# Altium schematic sheet Powering.SchDoc(Powering)
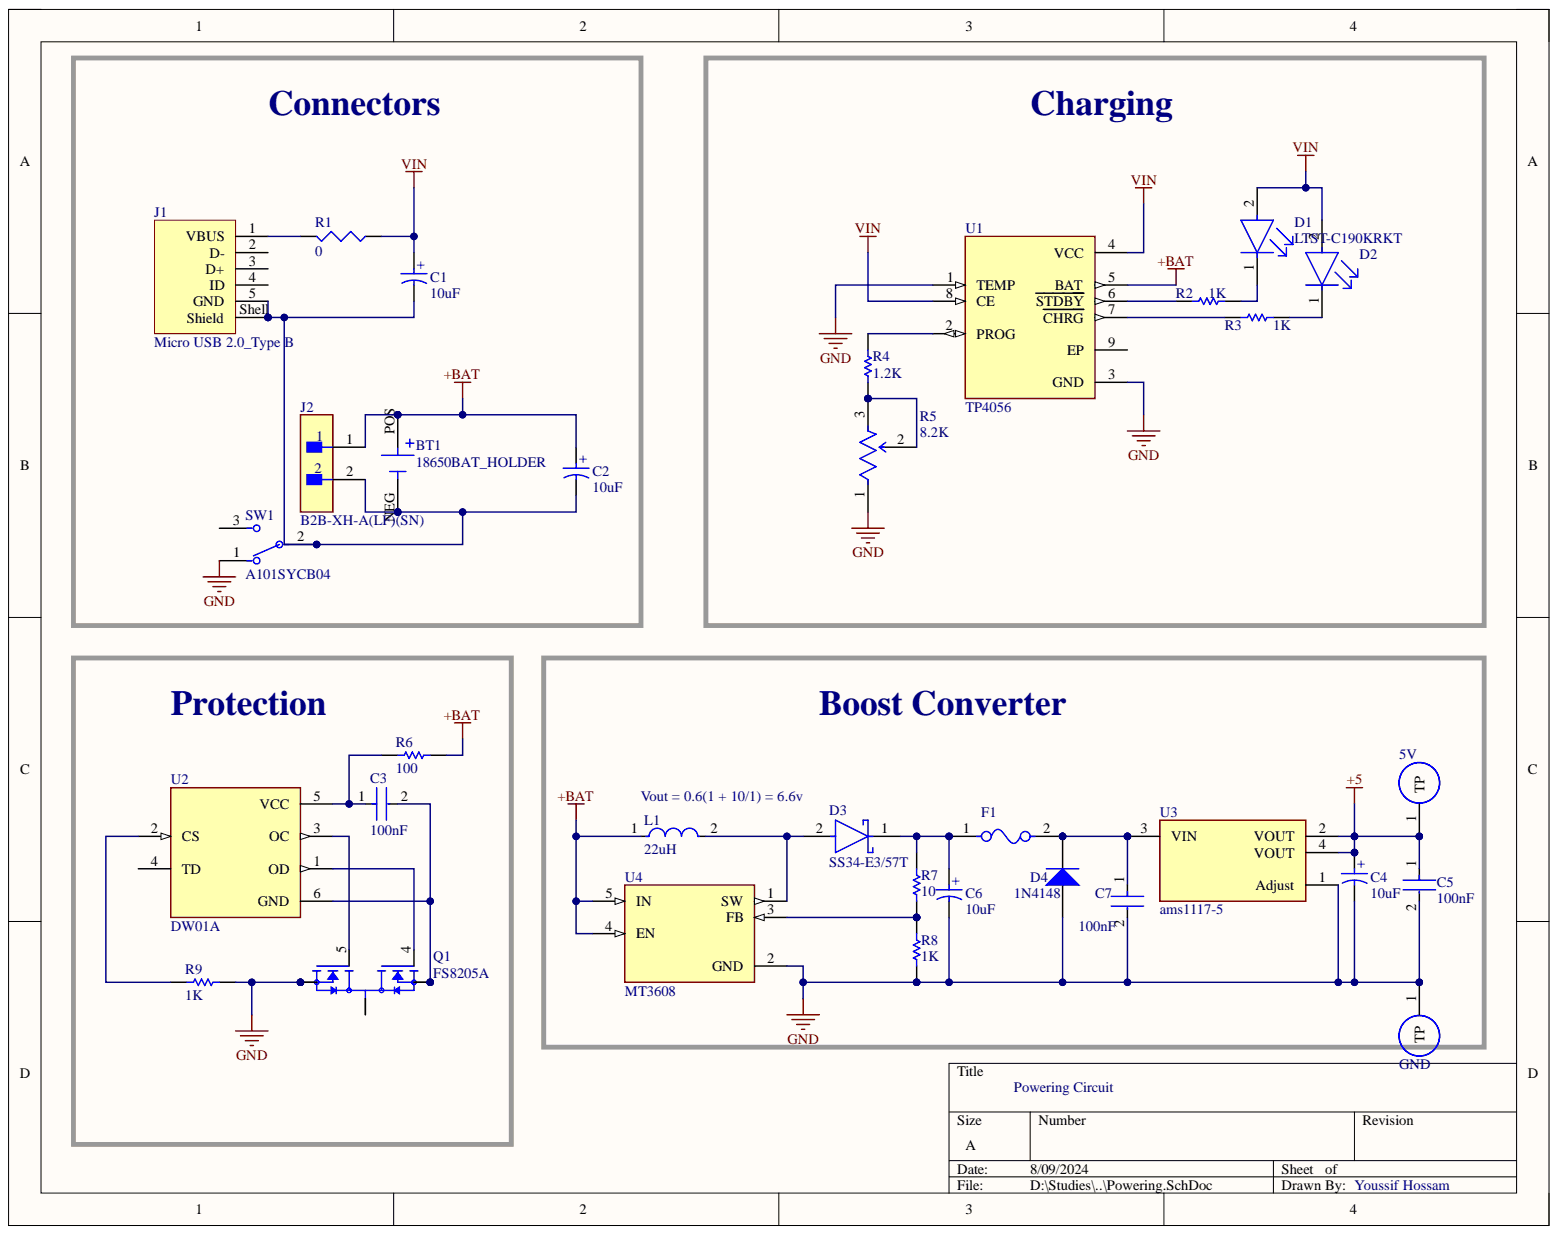
[diagram p0: Powering.SchDoc]

## Parts
5V  Test Point  [Test_Point]  (value not drawn)  (no BOM)
BT1  18650BAT_HOLDER  [18650BAT_HOLDER]
C1  10uF  [WCAP-ASLU_D3H5.5]
C2  10uF  [WCAP-ASLU_D3H5.5]
C3  100nF  [FP-C0402-BB-MFG]
C4  10uF  [WCAP-ASLU_D3H5.5]
C5  100nF  [FP-CGA3E-0_3-0_1-IPC_A]
C6  10uF  [WCAP-ASLU_D3H5.5]
C7  100nF  [FP-CGA3E-0_3-0_1-IPC_A]
D1  LTST-C190KRKT  [FP-LTST-C190KRKT-MFG]
D2  LTST-C190GKT  [FP-LTST-C190GKT-MFG]  (value not drawn)
D3  SS34-E3/57T  [FP-95402-MFG]
D4  1N4148  [DIOD-SOD123-2_V]
F1  0154004.DR  [FP-0154-MFG]  (value not drawn)
GND  Test Point  [Test_Point]  (value not drawn)  (no BOM)
J1  Micro USB 2.0_Type B  Connector Type: USB  [Micro USB 2.0_Type B]
J2  B2B-XH-A(LF)(SN)  [FP-B2B-XH-A_LF_SN-MFG]
L1  22uH  [FP-IHLP-2525CZ-FP_2_413x3-MFG]
Q1  FS8205A  [SOP65P640X120-8N]
R1  value 0  [J1-0603]
R2  1K  [RESC1005X40X25NL05T10]
R3  1K  [RESC1005X40X25NL05T10]
R4  1.2K  [RESC1608X55X25ML10T15]
R5  8.2K  [FP-TS53YL-MFG]
R6  100  [RESC1005X40X25NL05T10]
R7  10  [RESC1608X55X25ML10T15]
R8  1K  [RESC1608X55X25ML10T15]
R9  1K  [RESC1608X55X25ML10T15]
SW1  A101SYCB04  [FP-A101SYCB04-MFG]
U1  TP4056  [TP4056]
U2  DW01A  [SOT95P280X145-6N]
U3  ams1117-5  [FP-ams1117-IPC_C]
U4  MT3608  [SOT95P280X145-6N]

## Nets
+5: 5V.1 C4.1 C5.1 U3.2(VOUT) U3.4(VOUT)
+BAT: BT1.POS C2.1 J2.1 L1.1 R6.2 U1.5(BAT) U4.4(EN) U4.5(IN)
GND: C4.2 C5.2 C6.2 C7.2 D4.1 GND.1 Q1.6 Q1.7 R5.1 R8.1 R9.2 SW1.1 U1.1(TEMP) U1.3(GND) U3.1(Adjust) U4.2(GND)
VIN: C1.1 D1.2 D2.2 R1.1 U1.4(VCC) U1.8(CE)
NetBT1_NEG: BT1.NEG C1.2 C2.2 J1.5(GND) J1.Shell(Shield) J2.2 SW1.2
NetC3_1: C3.1 R6.1 U2.5(VCC)
NetC3_2: C3.2 Q1.2 Q1.3 U2.6(GND)
NetC6_1: C6.1 D3.1 F1.1 R7.2
NetC7_1: C7.1 D4.2 F1.2 U3.3(VIN)
NetD1_1: D1.1 R2.2
NetD2_1: D2.1 R3.2
NetD3_2: D3.2 L1.2 U4.1(SW)
NetJ1_1: J1.1(VBUS) R1.2
NetQ1_1: Q1.1 Q1.8
NetQ1_4: Q1.4 U2.1(OD)
NetQ1_5: Q1.5 U2.3(OC)
NetR2_1: R2.1 U1.6(STDBY)
NetR3_1: R3.1 U1.7(CHRG)
NetR4_1: R4.1 R5.2 R5.3
NetR4_2: R4.2 U1.2(PROG)
NetR7_1: R7.1 R8.2 U4.3(FB)
NetR9_1: R9.1 U2.2(CS)

Not connected: J1 2(D-) 3(D+) 4(ID); SW1 3; U1 9(EP); U2 4(TD)

## Library data of the parts
BT1 = 18650BAT_HOLDER: Battery Holder (Open) 18650 1 Cell PC Pin; MP: BK-18650-PC2; Availability: Unavailable; Component Kind: Standard; Package: None; Price: None; Library Name: YoussifLIB.SchLib
C1 C2 C4 C6 = WCAP-ASLU_D3H5.5: WCAP-ASLU Aluminum Electrolytic Capacitor, V-Chip, D4 x H5.5mm, 10uF, 6.3V; Manufacturer: Wurth Elektronik; Manufacturer Part Number: 865090140001; Capacitance Tolerance: +/-20%; Dissipation Factor: 26%; Endurance: 1000h; Leakage Current: 0.4uA; Ripple Current: 15mA; Technical Reference: ASBB055100M6R3DVCTAA000; Capacitance: 10uF; Category: Aluminum Electrolytic Capacitors; Component Kind: Standard; ComponentLink1Description: Website Link; ComponentLink1URL: https://www.we-online.com/redexpert/article/865090140001?ad; ComponentLink2Description: Datasheet Link; ComponentLink2URL: https://www.we-online.com/redexpert/spec/865090140001?ad; Diameter: 4mm; Height: 5.5mm; Match Code: WCAP-ASLU; Mount: Surface Mount; Operating Temperature Max: 85°C; Operating Temperature Min: -40°C; Packaging: Tape and Reel; Rated Voltage (DC): 6.3V; Library Name: YoussifLIB.SchLib
C3 = CMP-2008-02046-2: CAP CER 0.1UF 10V X5R 0402; Manufacturer: Kemet; Manufacturer Part Number: C0402C104K8PACTU; Capacitance: 0.10 μF; Material: Ceramic; Temperature Coefficient: 15%; Tolerance: 0.1; Value: 0.10 μF; Automotive: No; Category: Cap; Component Kind: Standard; ComponentLink2Description: Datasheet; ComponentLink2URL: https://content.kemet.com/datasheets/KEM_C1002_X7R_SMD.pdf; Device Class L1: Passive Components; Device Class L2: Capacitors; Device Class L3: Ceramic Capacitors; Digikey Description: CAP CER 0.1UF 10V X5R 0402; Height: 0.55mm; Ipc Land Pattern Name: CAPC10050X50; Lead Free: Yes; Library Name: Altium Content Vault; Mouser Description: Capacitor 100 nF 10 VDC 0402; Octopart: https://octopart.com/c0402c104k8pactu-kemet-140039; Package: C0402-BB; RoHS: TRUE; Temperature: -55°C to +125°C; Temperature Characteristic: X5R; Temperature Range High: +125°C; Temperature Range Low: -55°C; Voltage Rating: 10 V
C5 C7 = CMP-2000-07546-2: Cap Ceramic 100nF 50V X7R 10% Pad SMD 0603 +125°C Automotive T/R; Capacitance: 100nF; Case Code (Imperial): 0603; Case Code (Metric): 1608; Case/Package: 0603; Component Kind: Standard; Composition: Ceramic; Depth: 0.8mm; Dielectric: X7R; Height: 0.8mm; Lead Free: Lead Free; Length: 1.6mm; Library Name: Altium Content Vault; Max Operating Temperature: 125°C; Min Operating Temperature: -55°C; Mount: Surface Mount; Package Quantity: 1; Packaging: Tape and Reel; Radiation Hardening: No; Ratings: AEC-Q200; REACH SVHC: No SVHC; Termination: SMD/SMT; Thickness: 0.035inch; Tolerance: 10%; Voltage: 50V; Voltage Rating: 50V; Voltage Rating (DC): 50V; Weight: 7.1E-05oz; Width: 0.8mm
D1 = CMP-1674-00011-2: LED RED CLEAR CHIP SMD; Dominant Wavelength: 631nm; Forward Current: 30mA; Forward Voltage: 2.4V; Lens Color: Colorless; Lens Style: Diffused; Luminous Intensity: 54mcd; Number of Elements: 1; Power Dissipation: 75mW; Reverse Voltage: 5V; Test Current: 20mA; Viewing Angle: 130°; Wavelength: 639nm; Case Code (Imperial): 0603; Case Code (Metric): 1608; Case/Package: 0603; Color: Red; Component Kind: Standard; Depth: 0.8mm; Height: 0.8mm; Illumination Color: Red; Lead Free: Lead Free; Length: 1.6mm; Lens Transparency: Clear; Library Name: Altium Content Vault; Max Operating Temperature: 85°C; Min Operating Temperature: -55°C; Mount: Surface Mount; Number of LEDs: 1; Number of Pins: 2; Package Quantity: 1; Packaging: Tape and Reel; Power Rating: 75mW; Radiation Hardening: No; Width: 0.8mm
D2 = CMP-22017-000005-2: LED GREEN CLEAR CHIP SMD; Dominant Wavelength: 569nm; Forward Current: 30mA; Forward Voltage: 2.1V; Lens Color: Colorless; Luminous Intensity: 6mcd; Number of Elements: 1; Power Dissipation: 100mW; Reverse Voltage: 5V; Test Current: 10mA; Viewing Angle: 130°; Voltage: 2V; Wavelength: 565nm; Case Code (Imperial): 0603; Case Code (Metric): 1608; Case/Package: 0603; Color: Green; Component Kind: Standard; Depth: 0.8mm; Height: 0.8mm; Illumination Color: Green; Lead Free: Lead Free; Length: 1.6mm; Lens Style: Clear; Lens Transparency: Clear; Library Name: Altium Content Vault; Max Operating Temperature: 85°C; Min Operating Temperature: -55°C; Mount: Surface Mount; Number of LEDs: 1; Number of Pins: 2; Package Quantity: 1; Packaging: Tape and Reel; Power Rating: 100mW; Radiation Hardening: No; Width: 0.8mm
D3 = CMP-02539-000012-1: DIODE SCHOTTKY 40V 3A DO214AB; Manufacturer: Vishay; Max Junction Temp: +150°C; Max Surge Forward Current: 100A; Peak Reverse Current: 0.5mA; Standoff Height: 0mm; Automotive: No; Category: Diode; Component Kind: Standard; ComponentLink2Description: Datasheet; ComponentLink2URL: http://www.vishay.com/docs/88751/ss32.pdf; Device Class L1: Discrete Semiconductors; Device Class L2: Diodes; Device Class L3: Schottky Diodes; Digikey Description: DIODE SCHOTTKY 40V 3A DO214AB; Footprint Url: http://www.vishay.com/docs/95402/smc.pdf; Forward Current: 3A; Height: 2.62mm; Lead Free: Yes; Library Name: Altium Content Vault; Manufacturer Part Number: SS34-E3/57T; Max Repetitive Reverse Voltage: 40V; Mouser Description: Schottky Diodes & Rectifiers 3.0 Amp 40 Volt; Octopart: https://octopart.com/ss34-e3%2F57t-vishay-39552907; Package: 95402; RoHS: TRUE; Temperature: -55°C to +150°C; Temperature Range High: +150°C; Temperature Range Low: -55°C
D4 = CMP-2000-04932-2: Fast Switching Diode, 100 V, 0.15 A, -65 to 150 degC, 2-Pin?SOD123, RoHS, Tape and Reel; Brand: DiodesIncorporated; Configuration: Single; If - Forward Current: 0.15A; Ir - Reverse Current: 1uA; Max Surge Current: 2A; Peak Reverse Voltage: 100V; Recovery Time: 4ns; Unit Weight: 0.000353oz; Vf - Forward Voltage: 1.25V; Component Kind: Standard; Datasheet URL: http://www.farnell.com/datasheets/1915529.pdf; Datasheet Version: Rev. 24-2, 10/2013; Factory Pack Quantity: 3000; Height: 1.25mm; Length: 2.85mm; Library Name: Altium Content Vault; Manufacturer: DiodesIncorporated; Manufacturer URL: http://www.diodes.com/; Maximum Operating Temperature: +150C; Minimum Operating Temperature: -65C; Mounting Style: SMD/SMT; Operating Temperature Range: -65Cto+150C; Package / Case: SOD-123; Package Reference: SOD123-2; Package URL: http://www.diodes.com/datasheets/ds18004.pdf; Packaging: Reel; Product: SwitchingDiodes; Product Category: Diodes-GeneralPurpose,Power,Switching; RoHS: True; Series: 1N4148; Type: SwitchingDiode; Width: 1.7mm
F1 = CMP-08605-000003-1: FUSE BRD MNT 4A 125VAC/VDC 2SMD; Manufacturer: Littelfuse; Fuse Blow Speed: Fast; Max Fuse Ac Voltage: 125V; Automotive: No; Category: Fuse; Component Kind: Standard; ComponentLink2Description: Datasheet; ComponentLink2URL: https://www.littelfuse.com/~/media/electronics/datasheets/fuses/littelfuse_fuse_154_154t_154l_154tl_datasheet.pdf.pdf; Device Class L1: Circuit Protection; Device Class L2: Fuses; Device Class L3: unset; Digikey Description: FUSE BRD MNT 4A 125VAC/VDC 2SMD; Fuse Current: 4A; Height: 3.81mm; Lead Free: Yes; Library Name: Altium Content Vault; Manufacturer Part Number: 0154004.DR; Max Fuse Dc Voltage: 125V; Mouser Description: Surface Mount Fuses Fuseblock w/ fuse 4A OMNI BLOK 154; Octopart: https://octopart.com/0154004.dr-littelfuse-565861; Package: 0154; RoHS: TRUE; Temperature: -55°C to +125°C; Temperature Range High: +125°C; Temperature Range Low: -55°C
J1 = Micro USB 2.0_Type B: NexarPartId: 52645113; Contact Resistance: 30mΩ; Current Rating: 3A; Gender: Receptacle; Insulation Resistance: 1GΩ; Number of Contacts: 5; Operating Supply Voltage: 30V; USB Standard: USB 2.0; Component Kind: Standard; Contact Material: Gold; Contact Plating: Gold; Description: Micro USB 2.0_Type B; Features: Shielded; Height: 2.86mm; Lead Free: Lead Free; Max Operating Temperature: 85°C; Min Operating Temperature: -40°C; Mount: Horizontal, Surface Mount; Number of Pins: 5; Orientation: Horizontal; Packaging: Tape and Reel; REACH SVHC: Unknown; RoHS: Compliant; Termination: SMD/SMT; Voltage Rating: 30V; Library Name: YoussifLIB.SchLib
J2 = CMP-2000-05888-3: CONN HEADER VERT 2POS 2.5MM; Connector Type: Male Pin; Contact Resistance: 20mR; Current Rating: 3A; Insulation Resistance: 1GR; Max Voltage Rating (AC): 250V; Number of Contacts: 2; Wire/Cable Gauge: 22AWG; Color: Natural; Component Kind: Standard; Contact Material: Brass; Contact Plating: Tin; Contact Type: Male Pin; Depth: 5.75mm; Fastening Type: Detent; Housing Color: White; Housing Material: Polyamide; Lead Free: Lead Free; Lead Pitch: 2.5mm; Length: 7.4mm; Library Name: Altium Content Vault; Max Current Rating: 3A; Max Operating Temperature: 85°C; Max Voltage Rating (DC): 250V; Min Operating Temperature: -25°C; Mount: Through Hole; Number of Positions: 2; Number of Rows: 1; Orientation: Straight; Packaging: Bulk; Pitch: 2.5mm; REACH SVHC: No SVHC; Series: XH; Termination: Solder; Voltage Rating: 250V; Voltage Rating (AC): 250V
L1 = CMP-2000-06442-2: Commercial Inductors, Low DCR Series 22uH 2.9A 135mΩ 20%; Core Material: Ferrite; DC Resistance (DCR): 135mR; Saturation Current: 2.5A; Self Resonant Frequency: 1MHz; Shielding: Shielded; Test Frequency: 100kHz; Case Code (Imperial): 2525; Case Code (Metric): 6363; Case/Package: 2525; Component Kind: Standard; Contact Plating: Tin; Current Rating: 2.9A; Depth: 6.72mm; Height: 3mm; Height - Seated (Max): 0.118inch; Inductance: 22uH; Inductance Tolerance: 20%; Lead Free: Lead Free; Length: 7.24mm; Library Name: Altium Content Vault; Max DC Current: 2.9A; Max Operating Temperature: 125°C; Military Standard: Not; Min Operating Temperature: -55°C; Mount: Surface Mount; Number of Pins: 2; Package Quantity: 2000; Packaging: Tape and Reel; Radiation Hardening: No; RMS Current (Irms): 2.9A; Termination: SMD/SMT; Tolerance: 20%; Width: 0.255inch
Q1 = FS8205A: N/A; MF: Fortune Semiconductor; Availability: Not in stock; Check_prices: https://www.snapeda.com/parts/FS8205A/Fortune+Semiconductor/view-part/?ref=eda; Component Kind: Standard; MP: FS8205A; Package: Package; Price: None; SnapEDA_Link: https://www.snapeda.com/parts/FS8205A/Fortune+Semiconductor/view-part/?ref=snap; Library Name: YoussifLIB.SchLib
R1 = Res3: Resistor; Component Kind: Standard; LatestRevisionDate: 29-May-2009; LatestRevisionNote: IPC-7351 Footprint Added.; Library Name: Miscellaneous+Devices.IntLib; PackageDescription: Chip Resistor; PackageReference: J1-0603; Published: 8-Jun-2000; Signal Integrity: Res; Simulation: RESISTOR; Publisher: Altium Limited
R2 R3 R6 = CMP-1011-00001-2: Manufacturer: Yageo / Phycomp; Part Number: RC0402JR-070RL; Component Kind: Standard; Library Name: Altium Content Vault
R4 R9 = CMP-2100-03667-1: Chip Resistor, 0 Ohm, +/- 5%, 0.1 W, -55 to 155 degC, 0603 (1608 Metric), RoHS, Tape and Reel; Manufacturer: Yageo; Power Rating: 100mW; Resistance: 0mOhm; Temperature Coefficient: 200ppm/degreesC; Voltage Rating: 50V; Case Code (Imperial): 0603; Case Code (Metric): 1608; Case/Package: 0603; Component Kind: Standard; Composition: ThickFilm; Contact Plating: Tin; Datasheet URL: http://www.yageo.com/NewPortal/yageodocoutput?fileName=/pdf/R-Chip/PYu-RC_Group_51_RoHS_L_5.pdf; Datasheet Version: Rev. 5, 10/2016; Depth: 0.8mm; Height: 0.45mm; Lead Free: LeadFree; Length: 1.6mm; Library Name: Altium Content Vault; Manufacturer URL: http://www.yageo.com/; Max Operating Temperature: 155degC; Military Standard: Not; Min Operating Temperature: -55degC; Number of Pins: 2; Package Description: 2-Pin Surface Mount Device, Body 1.6 x 0.8 mm; Package Quantity: 5000; Package Reference: 0603; Packaging: TapeandReel; Radiation Hardening: No; REACH SVHC: NoSVHC; RoHSCompliant: true; Termination: SMD/SMT; Tolerance: 5%; Voltage Rating (DC): 50V; Width: 0.8mm
R5 = CMP-02410-000004-1: TRIMMER 10K OHM 0.25W GW TOP ADJ; Max Power Dissipation: 250mW; Number of Turns: 1; Resistance: 10kR; Resistance Tolerance: 20%; Temperature Coefficient: 100ppm/°C; Component Kind: Standard; Depth: 6.4mm; Height: 2.7mm; Lead Free: Lead Free; Length: 5mm; Library Name: Altium Content Vault; Max Operating Temperature: 125°C; Military Standard: Not; Min Operating Temperature: -55°C; Mount: Surface Mount; Package Quantity: 1; Packaging: Tape and Reel; Power Rating: 250mW; Radiation Hardening: No; Resistor Type: Cermet; RoHS Compliant: Yes; Series: TS53Y; Taper: Linear; Technology: CERMET; Termination: Gull Wing; Tolerance: 20%; Width: 5mm
R7 = CMP-2100-03667-1: Chip Resistor, 0 Ohm, +/- 5%, 0.1 W, -55 to 155 degC, 0603 (1608 Metric), RoHS, Tape and Reel; Manufacturer: Yageo; Power Rating: 100mW; Resistance: 7.5K; Temperature Coefficient: 200ppm/degreesC; Voltage Rating: 50V; Case Code (Imperial): 0603; Case Code (Metric): 1608; Case/Package: 0603; Component Kind: Standard; Composition: ThickFilm; Contact Plating: Tin; Datasheet URL: http://www.yageo.com/NewPortal/yageodocoutput?fileName=/pdf/R-Chip/PYu-RC_Group_51_RoHS_L_5.pdf; Datasheet Version: Rev. 5, 10/2016; Depth: 0.8mm; Height: 0.45mm; Lead Free: LeadFree; Length: 1.6mm; Library Name: Altium Content Vault; Manufacturer URL: http://www.yageo.com/; Max Operating Temperature: 155degC; Military Standard: Not; Min Operating Temperature: -55degC; Number of Pins: 2; Package Description: 2-Pin Surface Mount Device, Body 1.6 x 0.8 mm; Package Quantity: 5000; Package Reference: 0603; Packaging: TapeandReel; Radiation Hardening: No; REACH SVHC: NoSVHC; RoHSCompliant: true; Termination: SMD/SMT; Tolerance: 5%; Voltage Rating (DC): 50V; Width: 0.8mm
R8 = CMP-2100-03667-1: Chip Resistor, 0 Ohm, +/- 5%, 0.1 W, -55 to 155 degC, 0603 (1608 Metric), RoHS, Tape and Reel; Manufacturer: Yageo; Power Rating: 100mW; Temperature Coefficient: 200ppm/degreesC; Voltage Rating: 50V; Case Code (Imperial): 0603; Case Code (Metric): 1608; Case/Package: 0603; Component Kind: Standard; Composition: ThickFilm; Contact Plating: Tin; Datasheet URL: http://www.yageo.com/NewPortal/yageodocoutput?fileName=/pdf/R-Chip/PYu-RC_Group_51_RoHS_L_5.pdf; Datasheet Version: Rev. 5, 10/2016; Depth: 0.8mm; Height: 0.45mm; Lead Free: LeadFree; Length: 1.6mm; Library Name: Altium Content Vault; Manufacturer URL: http://www.yageo.com/; Max Operating Temperature: 155degC; Military Standard: Not; Min Operating Temperature: -55degC; Number of Pins: 2; Package Description: 2-Pin Surface Mount Device, Body 1.6 x 0.8 mm; Package Quantity: 5000; Package Reference: 0603; Packaging: TapeandReel; Radiation Hardening: No; REACH SVHC: NoSVHC; Resistance: 1K; RoHSCompliant: true; Termination: SMD/SMT; Tolerance: 5%; Voltage Rating (DC): 50V; Width: 0.8mm
SW1 = CMP-03408-000017-1: SWITCH TOGGLE SPDT 0.4VA 20V; Actuator Color: Chrome; Actuator Length: 10.41mm; Actuator Material: Phenolic; Actuator Type: Standard Round; Contact Current Rating: 400mA; Contact Resistance: 10mR; Electrical Life: 6000Cycles; Illumination Type: Non-Illuminated; Insulation Resistance: 30MR; Number of Poles: 1; Number of Positions: 2; Power Rating: 400mW; Switch Function: On-On; Component Kind: Standard; Contact Material: Copper; Contact Plating: Gold; Depth: 6.86mm; ELV: Compliant; Flammability Rating: UL94 V-1; Lead Free: Lead Free; Library Name: Altium Content Vault; Max Operating Temperature: 85°C; Max Voltage Rating (AC): 20V; Max Voltage Rating (DC): 20V; Min Operating Temperature: -30°C; Mount: Panel; Orientation: Straight; Package Quantity: 100; Packaging: Bulk; Radiation Hardening: No; REACH SVHC: No SVHC; RoHS Compliant: Yes; Series: Gemini A; Termination: PC Pins; Throw Configuration: SPDT; Voltage Rating (AC): 20V; Voltage Rating (DC): 20V
U1 = TP4056: Complete single cell Li-Ion battery with a constant current / constant voltage linear charger; MF: NanJing Top Power ASIC Corp.; Availability: Not in stock; Check_prices: https://www.snapeda.com/parts/TP4056/NanJing+Top+Power+ASIC+Corp./view-part/?ref=eda; Component Kind: Standard; MP: TP4056; Package: Package; Price: None; SnapEDA_Link: https://www.snapeda.com/parts/TP4056/NanJing+Top+Power+ASIC+Corp./view-part/?ref=snap; Library Name: YoussifLIB.SchLib
U2 = DW01A: One Cell Lithium-ion/Polymer Battery Protection IC; MF: Fortune Semiconductor; Check_prices: https://www.snapeda.com/parts/DW01A/Fortune+Semiconductor/view-part/?ref=eda; Component Kind: Standard; MP: DW01A; Package: SOT-23-6 Fortune Semiconductor; SnapEDA_Link: https://www.snapeda.com/parts/DW01A/Fortune+Semiconductor/view-part/?ref=snap; Library Name: YoussifLIB.SchLib
U3 = CMP-209535-000002-1: 1A LOW DROPOUT VOLTAGE REGULATOR; Component Kind: Standard; Library Name: Altium Content Vault; RoHS Compliant: Yes
U4 = MT3608: High Efficiency 1.2MHz2A Step Up Converter; MF: Aerosemi Technology Co., Ltd; Availability: Not in stock; Check_prices: https://www.snapeda.com/parts/MT3608/Aerosemi+Technology+Co.%252C+Ltd/view-part/?ref=eda; Component Kind: Standard; MP: MT3608; Package: Package; Price: None; SnapEDA_Link: https://www.snapeda.com/parts/MT3608/Aerosemi+Technology+Co.%252C+Ltd/view-part/?ref=snap; Library Name: YoussifLIB.SchLib
5V GND = Test Point: Library Name: YoussifLIB.SchLib
